# Altium schematic sheet TVR_Rev2_Microcontroller.SchDoc(TVR_Rev2_Microcontroller)
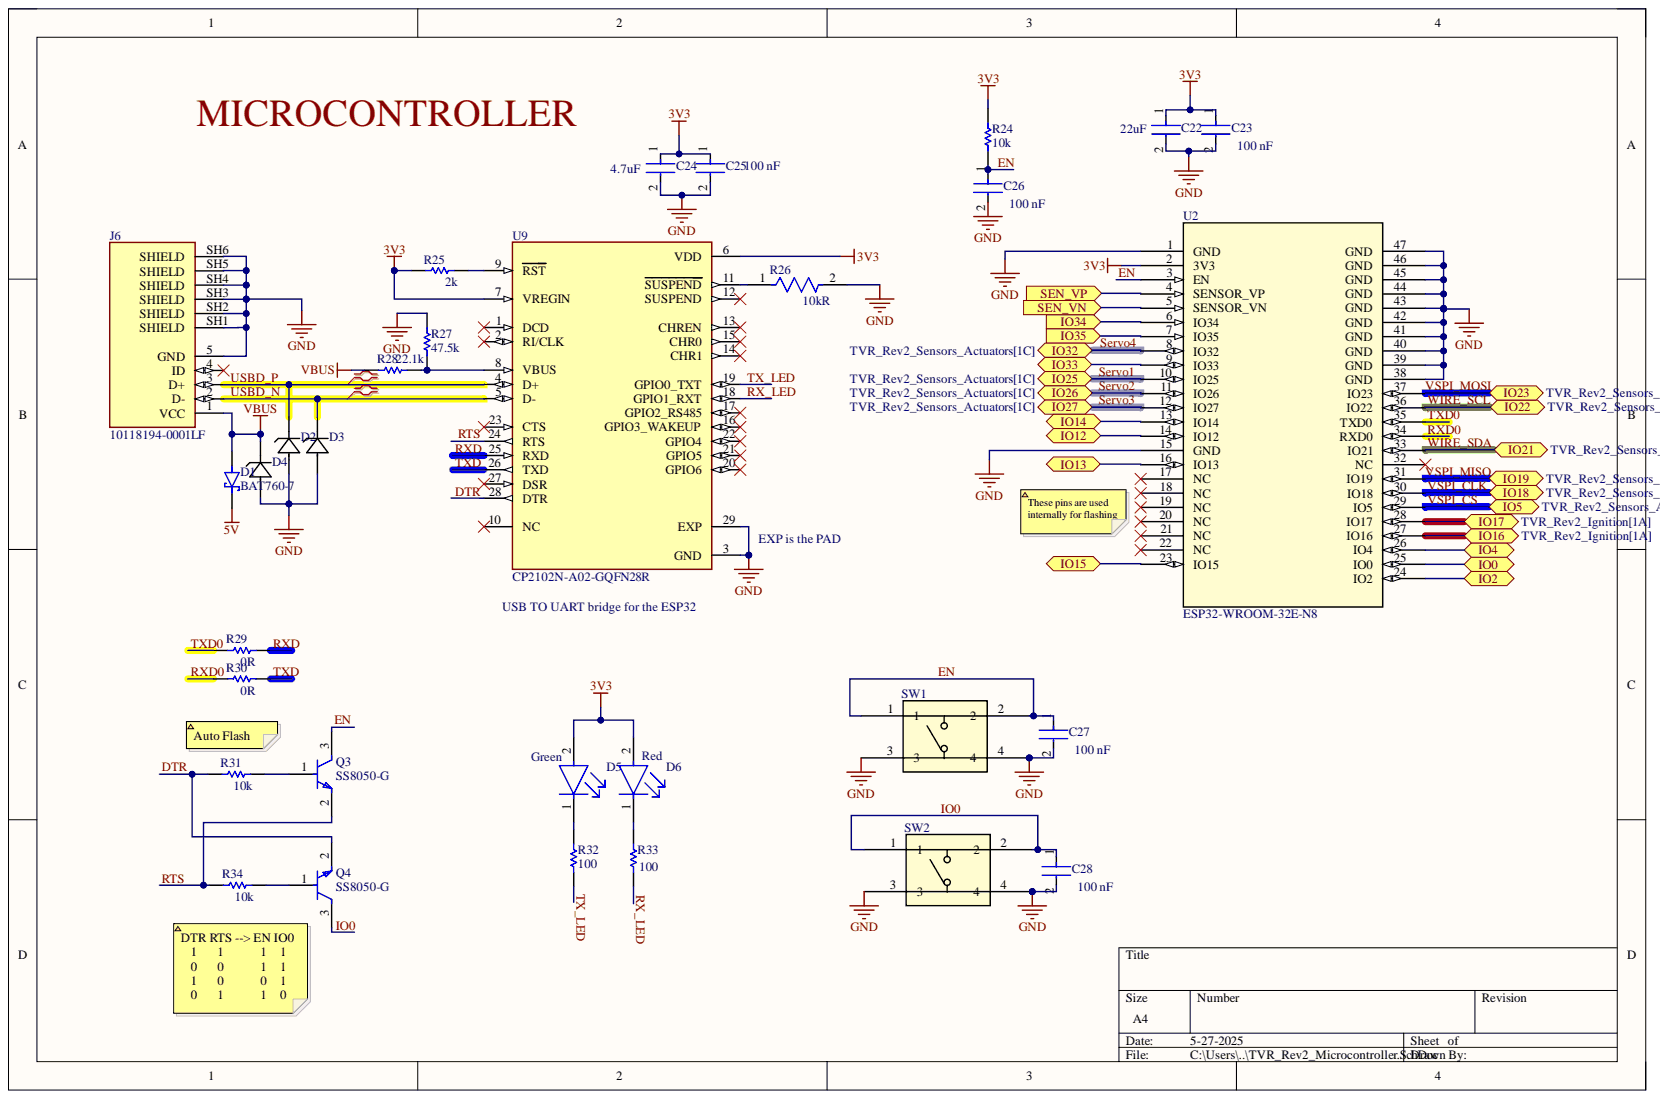
[diagram p0: TVR_Rev2_Microcontroller.SchDoc]

## Parts
C22  Capacitance: 22uF  [FP-GRM21B-0_2-e0_2_0_7-IPC_B]  (hidden comment: GRM21BR61E226ME44L)
C23  value 100 nF  [FP-CC0805-0_85-MFG]  (hidden comment: CC0805KRX7R9BB104)
C24  Capacitance: 4.7uF  [FP-GRM21B-0_15-IPC_B]  (hidden comment: GRM21BR61H475KE51L)
C25  value 100 nF  [FP-CC0805-0_85-MFG]  (hidden comment: CC0805KRX7R9BB104)
C26  value 100 nF  [FP-CC0805-0_85-MFG]  (hidden comment: CC0805KRX7R9BB104)
C27  value 100 nF  [FP-CC0805-0_85-MFG]  (hidden comment: CC0805KRX7R9BB104)
C28  value 100 nF  [FP-CC0805-0_85-MFG]  (hidden comment: CC0805KRX7R9BB104)
D1  BAT760-7  [SOD2513X120N]
D2  CPDQ5V0-HF  [CPDQ]  (value not drawn)
D3  CPDQ5V0-HF  [CPDQ]  (value not drawn)
D4  CPDQ5V0-HF  [CPDQ]  (value not drawn)
D5  Color: Green  [FP-LTST-C171GKT-MFG]  (hidden comment: LTST-C171GKT)
D6  Color: Red  [FP-LTST-C171GKT-MFG]  (hidden comment: LTST-C171KRKT)
J6  10118194-0001LF  [AMPHENOL_10118194-0001LF]
Q3  SS8050-G  [FP-SS8050-G-MFG]
Q4  SS8050-G  [FP-SS8050-G-MFG]
R24  Resistance: 10k  [RESC2013X60X35NL10T20]  (hidden comment: RC0805FR-0710KL)
R25  Resistance: 2k  [RESC2013X70X40NL20T20]  (hidden comment: ERJ-6ENF2001V)
R26  Resistance: 10kR  [FP-RMCF0805-IPC_A]  (hidden comment: RMCF0805JT10K0)
R27  Resistance: 47.5k  [RESC2013X70X40NL20T20]  (hidden comment: ERJ-6ENF4752V)
R28  Resistance: 22.1k  [RESC2013X50X30LL20T20]  (hidden comment: CRCW080522K1FKTA)
R29  CRCW08050000Z0EA  [RESC2013X50X30LL20T20]  (value not drawn)
R30  Resistance: 0R  [RESC2013X50X30LL20T20]  (hidden comment: CRCW08050000Z0EA)
R31  Resistance: 10k  [RESC2013X60X35NL10T20]  (hidden comment: RC0805FR-0710KL)
R32  ERA6AEB101V  [RESC2013X60X40ML20T25]  (value not drawn)
R33  ERA6AEB101V  [RESC2013X60X40ML20T25]  (value not drawn)
R34  Resistance: 10k  [RESC2013X60X35NL10T20]  (hidden comment: RC0805FR-0710KL)
SW1  PTS645SM43SMTR92LFS  [SWITCH_43SMTR92LFS]  (value not drawn)
SW2  PTS645SM43SMTR92LFS  [SWITCH_43SMTR92LFS]  (value not drawn)
U2  ESP32-WROOM-32E-N8  [ESP32­WROOM­32E_EXP]
U9  CP2102N-A02-GQFN28R  [IC_CP2102N-A02-GQFN28R]

## Ports
IO0, IO2, IO4, IO5, IO12, IO13, IO14, IO15, IO16, IO17, IO18, IO19, IO21, IO22, IO23, IO25, IO26, IO27, IO32, IO33, IO34, IO35, SEN_VN, SEN_VP

## Nets
3V3: C22.1 C23.1 C24.1 C25.1 D5.2 D6.2 R24.2 R25.1 U2.2(3V3) U9.6(VDD) U9.7(VREGIN)
5V: D1.C
GND: C22.2 C23.2 C24.2 C25.2 C26.2 C27.2 C28.2 D2.2 D3.2 D4.2 J6.5(GND) J6.SH1(SHIELD) J6.SH2(SHIELD) J6.SH3(SHIELD) J6.SH4(SHIELD) J6.SH5(SHIELD) J6.SH6(SHIELD) R26.2 R27.2 SW1.3 SW1.4 SW2.3 SW2.4 U2.1(GND) U2.15(GND) U2.38(GND) U2.39(GND) U2.40(GND) U2.41(GND) U2.42(GND) U2.43(GND) U2.44(GND) U2.45(GND) U2.46(GND) U2.47(GND) U9.3(GND) U9.29(EXP)
VBUS: D1.A D4.1 J6.1(VCC) R28.1
DTR: Q4.2 R31.1 U9.28(DTR)
EN: C26.1 C27.1 Q3.3 R24.1 SW1.1 SW1.2 U2.3(EN)
IO0: C28.1 Q4.3 SW2.1 SW2.2
IO2: U2.24(IO2) ; port IO2
IO4: U2.26(IO4) ; port IO4
IO12: U2.14(IO12) ; port IO12
IO13: U2.16(IO13) ; port IO13
IO14: U2.13(IO14) ; port IO14
IO15: U2.23(IO15) ; port IO15
IO16: U2.27(IO16) ; port IO16
IO17: U2.28(IO17) ; port IO17
IO33: U2.9(IO33) ; port IO33
IO34: U2.6(IO34) ; port IO34
IO35: U2.7(IO35) ; port IO35
RTS: Q3.2 R34.1 U9.24(RTS)
RX_LED: R33.1 U9.18(GPIO1_RXT)
RXD: R29.2 U9.25(RXD)
RXD0: R30.1 U2.34(RXD0)
SEN_VN: U2.5(SENSOR_VN) ; port SEN_VN
SEN_VP: U2.4(SENSOR_VP) ; port SEN_VP
Servo1: U2.10(IO25) ; port IO25
Servo2: U2.11(IO26) ; port IO26
Servo3: U2.12(IO27) ; port IO27
Servo4: U2.8(IO32) ; port IO32
TX_LED: R32.1 U9.19(GPIO0_TXT)
TXD: R30.2 U9.26(TXD)
TXD0: R29.1 U2.35(TXD0)
USBD_N: D3.1 J6.2(D-) U9.5(D-)
USBD_P: D2.1 J6.3(D+) U9.4(D+)
VSPI_CLK: U2.30(IO18) ; port IO18
VSPI_CS: U2.29(IO5) ; port IO5
VSPI_MISO: U2.31(IO19) ; port IO19
VSPI_MOSI: U2.37(IO23) ; port IO23
WIRE_SCL: U2.36(IO22) ; port IO22
WIRE_SDA: U2.33(IO21) ; port IO21
NetD5_1: D5.1 R32.2
NetD6_1: D6.1 R33.2
NetQ3_1: Q3.1 R31.2
NetQ4_1: Q4.1 R34.2
NetR25_2: R25.2 U9.9(RST)
NetR26_1: R26.1 U9.11(SUSPEND)
NetR27_1: R27.1 R28.2 U9.8(VBUS)
NetU2_25: U2.25(IO0) ; port IO0

Not connected: J6 4(ID); U2 17(NC) 18(NC) 19(NC) 20(NC) 21(NC) 22(NC) 32(NC); U9 1(DCD) 2(RI/CLK) 10(NC) 12(SUSPEND) 13(CHREN) 14(CHR1) 15(CHR0) 16(GPIO3_WAKEUP) 17(GPIO2_RS485) 20(GPIO6) 21(GPIO5) 22(GPIO4) 23(CTS) 27(DSR)

## Library data of the parts
C22 = CMP-2007-03222-3: Chip Multilayer Ceramic Capacitors for General Purpose, 0805, 22uF, X5R, 15%, 20%, 25V; Case Code (Imperial): 0805; Case Code (Metric): 2012; Case/Package: 0805; Component Kind: Standard; Depth: 1.25mm; Dielectric: X5R; Height: 1.25mm; Lead Free: Lead Free; Length: 2mm; Library Name: Altium Content Vault; Material: Ceramic; Max Operating Temperature: 85°C; Min Operating Temperature: -55°C; Mount: Surface Mount; Package Quantity: 3000; Packaging: Tape and Reel; REACH SVHC: No SVHC; Resistor Type: Ceramic; Termination: SMD/SMT; Thickness: 0.057inch; Tolerance: 20%; Voltage: 25V; Voltage Rating: 25V; Voltage Rating (DC): 25V; Weight: 0.000335oz; Width: 1.25mm
C23 C25-C28 = CMP-2100-03606-3: CAP CER 0.1UF 50V X7R 0805; Manufacturer: Yageo; Material: Ceramic; Tolerance: 0.1; Automotive: No; Capacitance: 100 nF; Category: Cap; Component Kind: Standard; ComponentLink2Description: Datasheet; ComponentLink2URL: http://www.yageo.com/documents/recent/UPY-GPHC_Y5V_6.3V-to-50V_8.pdf; Device Class L1: Passive Components; Device Class L2: Capacitors; Device Class L3: Ceramic Capacitors; Digikey Description: CAP CER 0.1UF 50V X7R 0805; Footprint Url: http://www.yageo.com/exep/pages/download/literatures/UPY-C_GEN_24.pdf; Height: 0.95mm; Ipc Land Pattern Name: CAPC200125X85; Lead Free: Yes; Library Name: Altium Content Vault; Manufacturer Part Number: CC0805KRX7R9BB104; Mouser Description: Multilayer Ceramic Capacitors MLCC - SMD/SMT 100nF 50V X7R 10%; Octopart: https://octopart.com/cc0805krx7r9bb104-yageo-192993; Package: CC0805-0.85; RoHS: TRUE; Temperature: -30°C to +85°C; Temperature Characteristic: X7R; Temperature Range High: +85°C; Temperature Range Low: -30°C; Voltage Rating: 50 V
C24 = CMP-06035-059131-1: Chip Multilayer Ceramic Capacitors for General Purpose, 0805, 4.7uF, X5R, 15%, 10%, 50V; Case Code (Imperial): 0805; Case Code (Metric): 2012; Case/Package: 0805; Component Kind: Standard; Depth: 1.25mm; Dielectric: X5R; Height: 1.25mm; Lead Free: Lead Free; Length: 2mm; Library Name: Altium Content Vault; Material: Ceramic; Max Operating Temperature: 85°C; Min Operating Temperature: -55°C; Mount: Surface Mount; Packaging: Tape and Reel; REACH SVHC: No SVHC; Thickness: 0.055inch; Tolerance: 10%; Voltage Rating: 50V; Voltage Rating (DC): 50V; Width: 0.049inch
D1 = BAT760-7: Diode Schottky 30V 1A 2-Pin SOD-323 T/R; MF: Diodes Zetex; Availability: Good; BuyPart: https://www.snapeda.com/parts/BAT760-7/Zetex/view-part/4817231/?ref=eda; Component Kind: Standard; DigiKey-Purchase-URL: https://snapeda.com/shop?store=DigiKey&id=4817231; Library Name: BAT760-7.IntLib; Mouser-Purchase-URL: https://snapeda.com/shop?store=Mouser&id=4817231; MP: BAT760-7; Package: None; Price: None; SnapEDA_Link: https://www.snapeda.com/parts/BAT760-7/Zetex/view-part/4817231/?ref=snap
D2-D4 = CPDQ5V0-HF: No Description Available; Mfr_Name: Comchip; Component Kind: Standard; Library Name: CPDQ5V0-HF.IntLib
D5 = CMP-22017-000007-1: LED GREEN CLEAR CHIP SMD; Dominant Wavelength: 569nm; Forward Current: 30mA; Forward Voltage: 2.1V; Lens Color: Colorless; Lens Style: Diffused; Luminous Intensity: 12mcd; Number of Elements: 1; Power Dissipation: 100mW; Reverse Voltage: 5V; Test Current: 10mA; Viewing Angle: 130°; Wavelength: 585nm; Case Code (Imperial): 0805; Case Code (Metric): 2012; Case/Package: 0805; Component Kind: Standard; Depth: 1.25mm; Height: 0.8mm; Illumination Color: Green; Lead Free: Lead Free; Length: 2mm; Lens Transparency: Clear; Library Name: Altium Content Vault; Max Operating Temperature: 85°C; Min Operating Temperature: -55°C; Mount: Surface Mount; Number of LEDs: 1; Number of Pins: 2; Package Quantity: 1; Packaging: Tape and Reel; Power Rating: 100mW; Radiation Hardening: No; Width: 1.25mm
D6 = CMP-22017-000007-1: LED RED CLEAR CHIP SMD; Dominant Wavelength: 569nm; Forward Current: 30mA; Forward Voltage: 2.1V; Lens Color: Colorless; Lens Style: Diffused; Luminous Intensity: 12mcd; Number of Elements: 1; Power Dissipation: 100mW; Reverse Voltage: 5V; Test Current: 10mA; Viewing Angle: 130°; Wavelength: 585nm; Case Code (Imperial): 0805; Case Code (Metric): 2012; Case/Package: 0805; Component Kind: Standard; Depth: 1.25mm; Height: 0.8mm; Illumination Color: Red; Lead Free: Lead Free; Length: 2mm; Lens Transparency: Clear; Library Name: Altium Content Vault; Max Operating Temperature: 85°C; Min Operating Temperature: -55°C; Mount: Surface Mount; Number of LEDs: 1; Number of Pins: 2; Package Quantity: 1; Packaging: Tape and Reel; Power Rating: 100mW; Radiation Hardening: No; Width: 1.25mm
J6 = 10118194-0001LF: Micro USB, Input Output Connectors, B TYPE RECEPTACLE with flange; MF: Amphenol ICC; Check_prices: https://www.snapeda.com/parts/10118194-0001LF/Amphenol+FCI/view-part/?ref=eda; Component Kind: Standard; Library Name: 10118194-0001LF.IntLib; MP: 10118194-0001LF; Package: None; SnapEDA_Link: https://www.snapeda.com/parts/10118194-0001LF/Amphenol+FCI/view-part/?ref=snap
Q3 Q4 = CMP-20235-000001-1: NPN TRANSISTOR 1.5A 40V SOT-23 R; Collector Emitter Breakdown Voltage: 25V; Collector Emitter Voltage (VCEO): 400mV; Max Power Dissipation: 300mW; Transition Frequency: 100MHz; Case/Package: TO-236-3; Component Kind: Standard; Library Name: Altium Content Vault; Max Breakdown Voltage: 25V; Max Collector Current: 1.5A; Mount: Surface Mount; Packaging: Tape and Reel; RoHS Compliant: Yes
R24 R31 R34 = CMP-1659-00013-4: Chip Resistor, 10 KOhm, +/- 1%, 0.125 W, -55 to 155 degC, 0805 (2012 Metric), RoHS, Tape and Reel; Manufacturer: Yageo; Voltage Rating: 150 V; Component Kind: Standard; ComponentLink1Description: Manufacturer URL; ComponentLink1URL: http://www.yageo.com/; ComponentLink2Description: Datasheet; ComponentLink2URL: http://www.yageo.com/documents/recent/PYu-RC_Group_51_RoHS_L_04.pdf; DatasheetVersion: Rev. 4, 02/2016; Height: 0.5 mm; Length: 2 mm; Library Name: Altium Content Vault; Max Operating Temperature: 155 degC; Min Operating Temperature: -55 degC; Mount: Surface Mount; Mounting Technology: Surface Mount; Package Quantity: 5000; PackageDescription: 2-Pin Surface Mounted Device, Body 2 x 1.25 mm; PackageReference: 0805; Packaging: Tape and Reel; Pins: 2; REACH SVHC: No SVHC; RoHSCompliant: true
R25 = CMP-2001-00026-5: Chip Resistor, 2 KOhm, +/- 1%, 0.125 W, -55 to 155 degC, 0805 (2012 Metric), RoHS, Tape and Reel; Manufacturer: Panasonic; Voltage Rating: 150 V; Component Kind: Standard; ComponentLink1Description: Manufacturer URL; ComponentLink1URL: http://www.panasonic.com/; ComponentLink2Description: Datasheet; ComponentLink2URL: http://industrial.panasonic.com/cdbs/www-data/pdf/RDA0000/AOA0000C86.pdf; DatasheetVersion: 04/2015; Height: 0.6 mm; Length: 2 mm; Library Name: Altium Content Vault; Max Operating Temperature: 155 degC; Min Operating Temperature: -55 degC; Mount: Surface Mount; Mounting Technology: Surface Mount; Package Quantity: 5000; PackageDescription: 2-Pin Surface Mount Device, Body 2 x 1.25 mm; PackageReference: 0805; Packaging: Tape and Reel; REACH SVHC: Unknown; RoHSCompliant: true
R26 = CMP-26527-002663-1: 10kΩ ±5% 0.125W 0805 Thick Film Chip Resistor AEC-Q200 compliant; Max Power Dissipation: 125mW; Temperature Coefficient: 100ppm/°C; Case Code (Imperial): 0805; Case Code (Metric): 2012; Case/Package: 0805; Component Kind: Standard; Construction: Rectangular; Contact Plating: Tin; Depth: 1.25mm; Features: Automotive AEC-Q200; Height: 0.026inch; Lead Free: Lead Free; Length: 2mm; Library Name: Altium Content Vault; Max Operating Temperature: 155°C; Military Standard: Not; Min Operating Temperature: -55°C; Mount: Surface Mount; Number of Terminations: 2; Packaging: Tape and Reel; Power Rating: 125mW; Radiation Hardening: No; Resistor Type: Thick Film; RoHS Compliant: Yes; Series: RMCF; Size Code: 0805; Tolerance: 5%; Width: 0.049inch
R27 = CMP-1013-00700-2: Manufacturer: Panasonic; Component Kind: Standard; Library Name: Altium Content Vault; Part Number: ERJ-6ENF4752V
R28 = CMP-1013-00660-2: Manufacturer: Vishay Dale; Component Kind: Standard; Library Name: Altium Content Vault; Part Number: CRCW080522K1FKTA
R29 = CMP-2001-04896-1: Manufacturer: Vishay Dale; Resistance: 0R; Component Kind: Standard; Library Name: Altium Content Vault; Part Number: CRCW08050000Z0EA
R30 = CMP-2001-04896-1: Manufacturer: Vishay Dale; Component Kind: Standard; Library Name: Altium Content Vault; Part Number: CRCW08050000Z0EA
R32 R33 = CMP-2001-00580-1: Manufacturer: Panasonic; Resistance: 100; Component Kind: Standard; Library Name: Altium Content Vault; Part Number: ERA6AEB101V
SW1 SW2 = PTS645SM43SMTR92LFS: No Description Available; Mfr_Name: C&K Components; Component Kind: Standard; Library Name: PTS645SM43SMTR92LFS.IntLib; RefDes: RefDes; Type: Type
U2 = ESP32-WROOM-32E-N8: No Description Available; Mfr_Name: Espressif Systems; Component Kind: Standard; Library Name: ESP32-WROOM-32E-N8.IntLib; RefDes: RefDes; Type: Type
U9 = CP2102N-A02-GQFN28R: USB Bridge, USB to UART USB 2.0 UART Interface 28-QFN (5x5); MF: Silicon Labs; Check_prices: https://www.snapeda.com/parts/CP2102N-A02-GQFN28R/Silicon+Labs/view-part/?ref=eda; Component Kind: Standard; Library Name: CP2102N-A02-GQFN28R.IntLib; MP: CP2102N-A02-GQFN28R; Package: None; SnapEDA_Link: https://www.snapeda.com/parts/CP2102N-A02-GQFN28R/Silicon+Labs/view-part/?ref=snap
